# Altium schematic sheet Microcontroller_CC1350.SchDoc
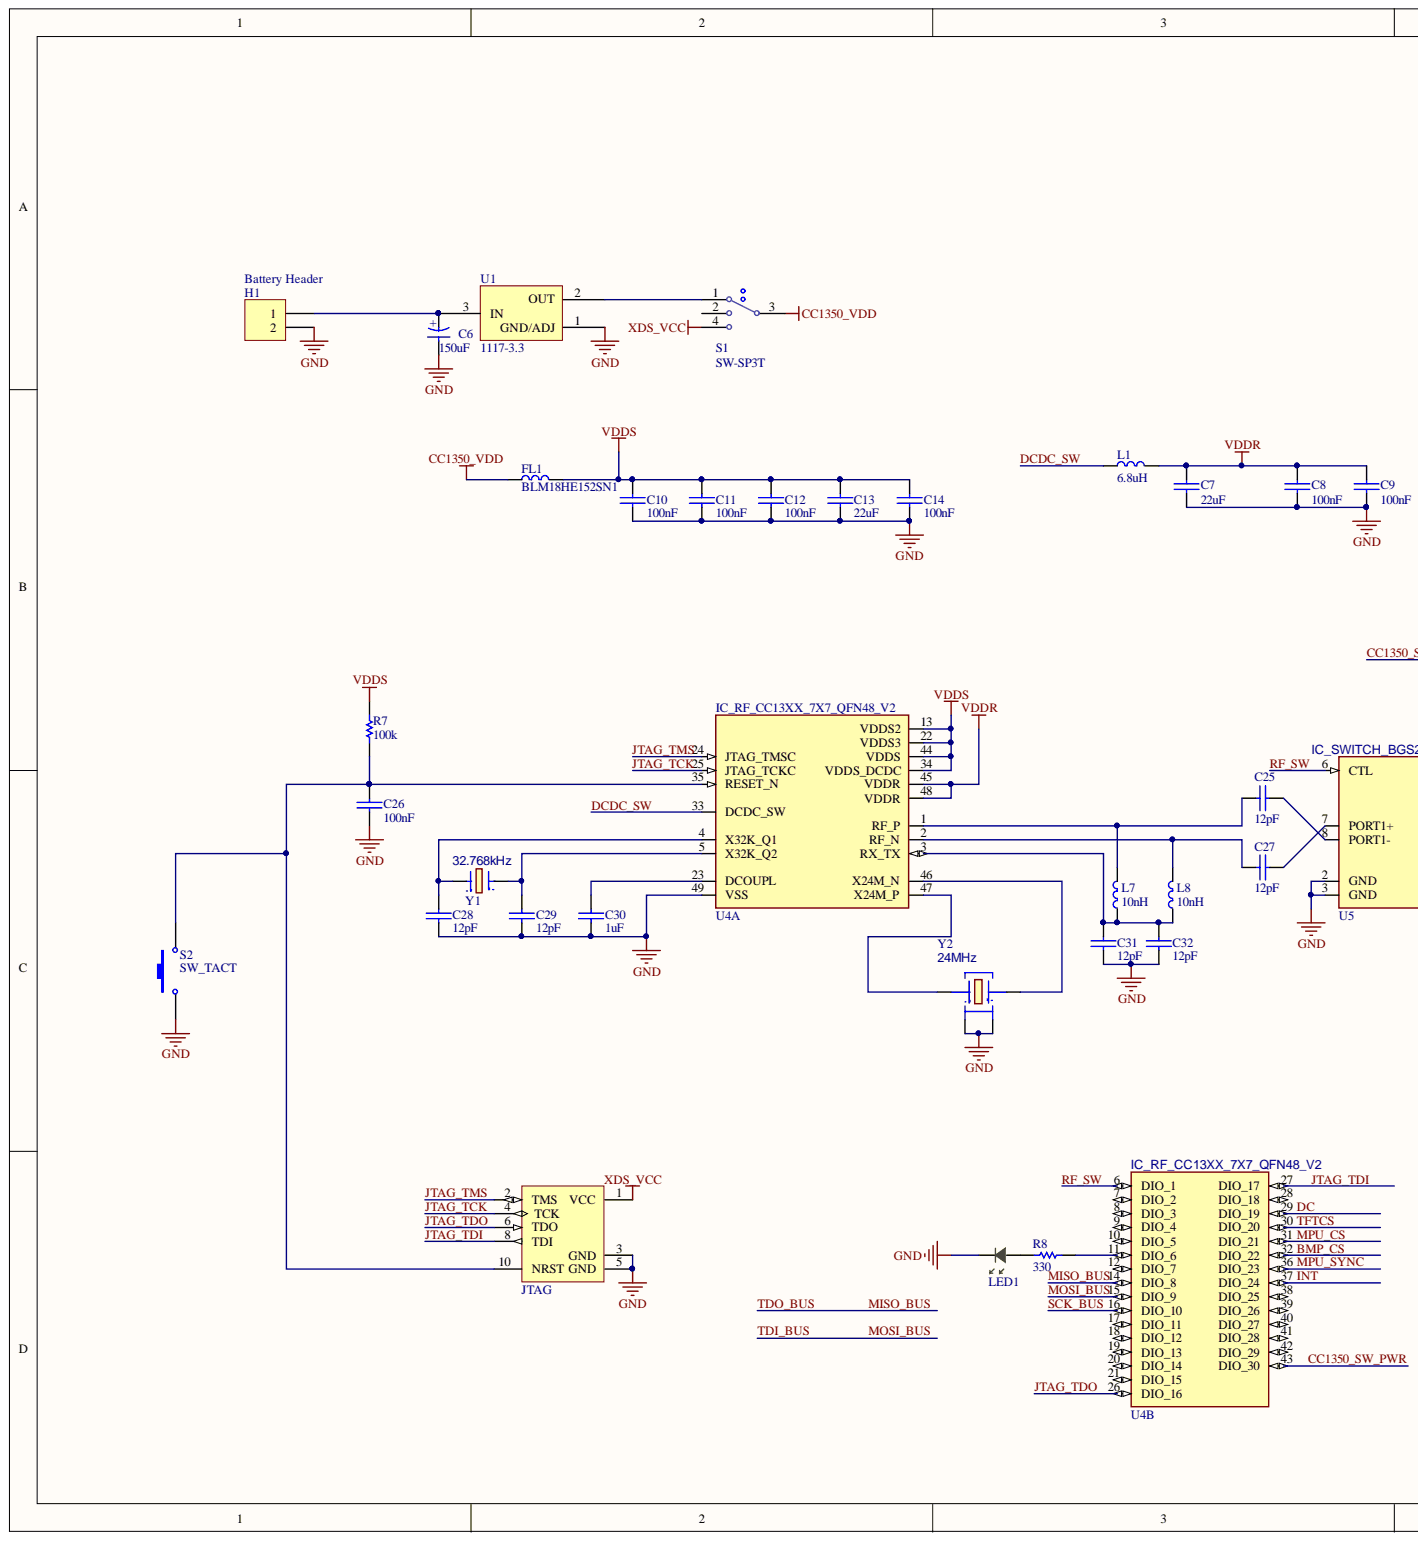
[diagram p0: Microcontroller_CC1350.SchDoc, left]
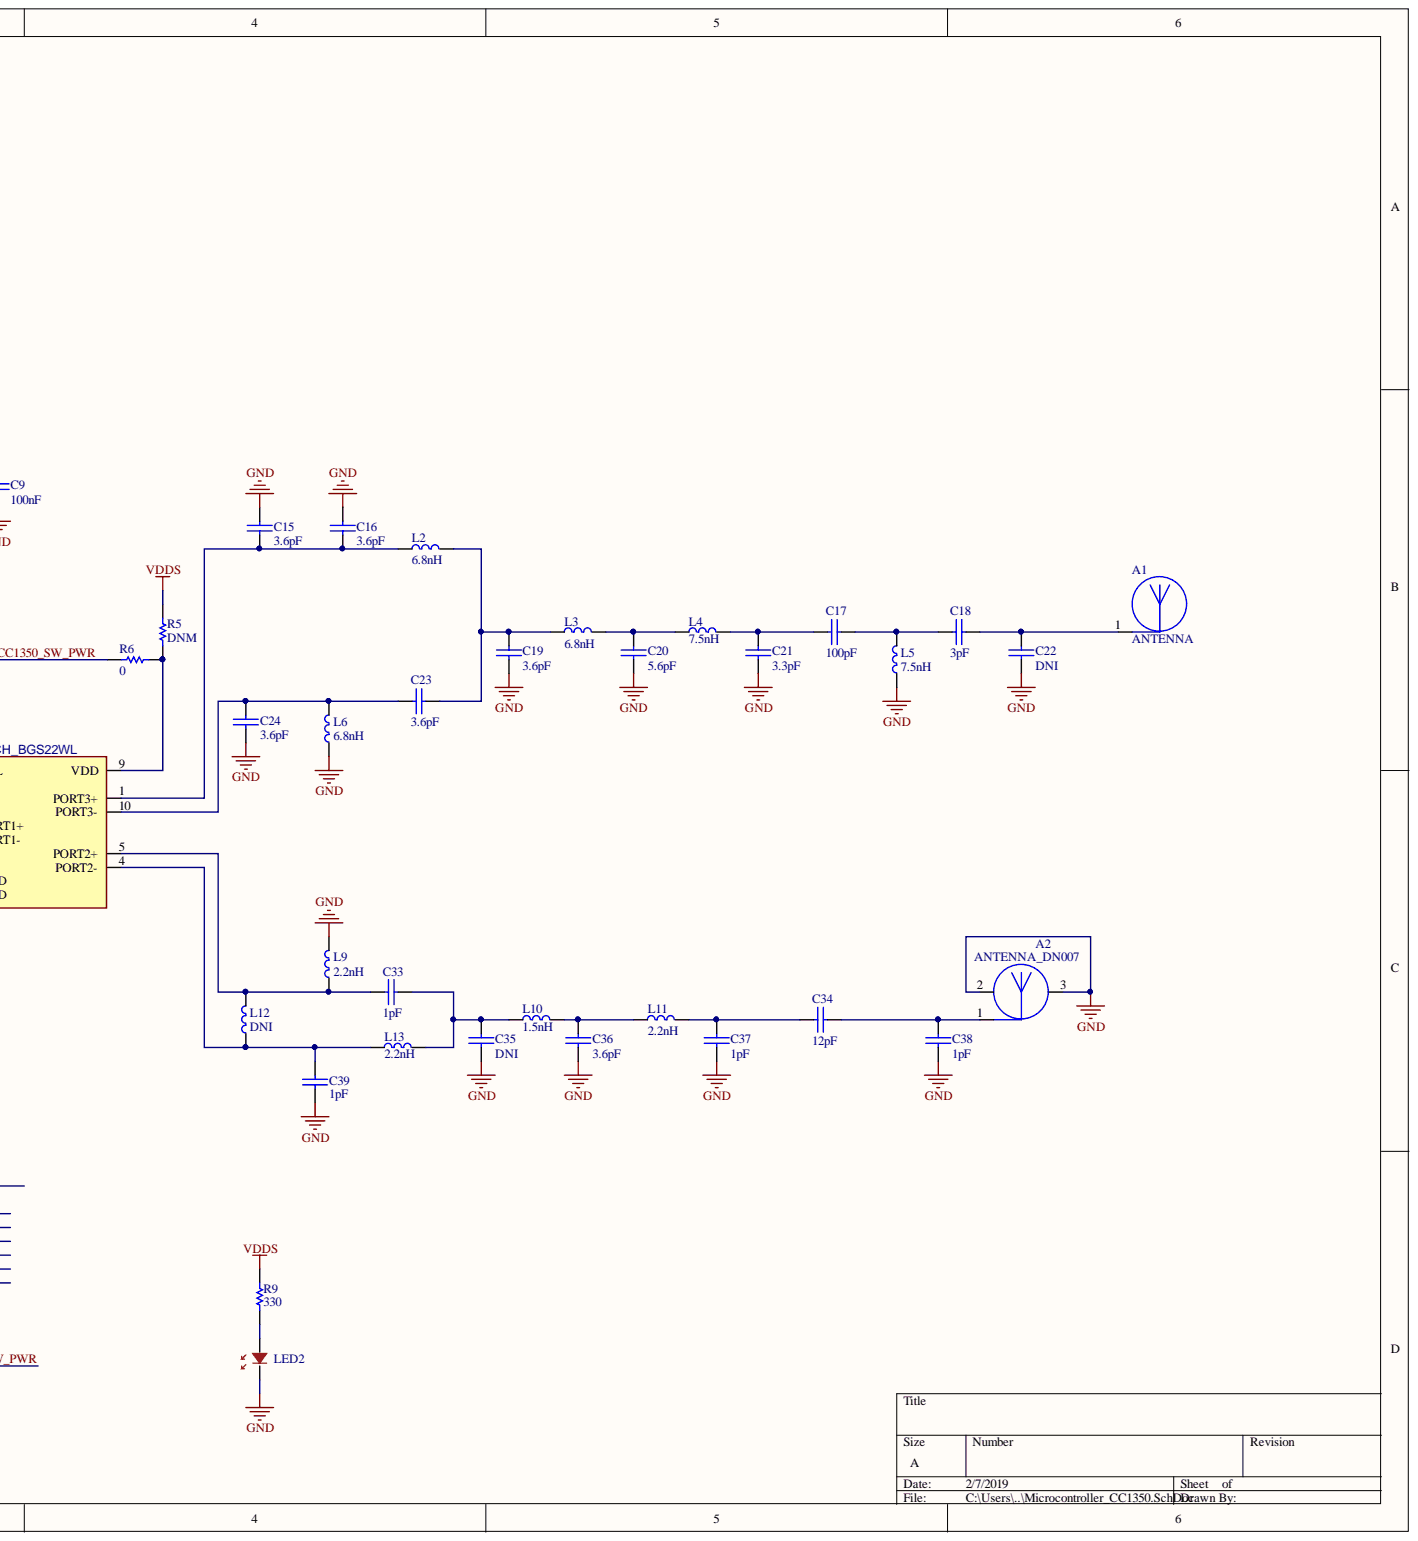
[diagram p1: Microcontroller_CC1350.SchDoc, right]

## Parts
A1  ANTENNA  [Sub-1GHz-Antenna]
A2  ANTENNA_DN007  [Inverted_F_Antenna]
C6  150uF  [2312 [IMP] Polar]
C7  22uF  [0603[IMP]]
C8  100nF  [0402[IMP]]
C9  100nF  [0402[IMP]]
C10  100nF  [0402[IMP]]
C11  100nF  [0402[IMP]]
C12  100nF  [0402[IMP]]
C13  22uF  [0603[IMP]]
C14  100nF  [0402[IMP]]
C15  3.6pF  [0402[IMP]]
C16  3.6pF  [0402[IMP]]
C17  100pF  [0402[IMP]]
C18  3pF  [0402[IMP]]
C19  3.6pF  [0402[IMP]]
C20  5.6pF  [0402[IMP]]
C21  3.3pF  [0402[IMP]]
C22  DNI  [0402[IMP]]
C23  3.6pF  [0402[IMP]]
C24  3.6pF  [0402[IMP]]
C25  12pF  [0402[IMP]]
C26  100nF  [0402[IMP]]
C27  12pF  [0402[IMP]]
C28  12pF  [0402[IMP]]
C29  12pF  [0402[IMP]]
C30  1uF  [0402[IMP]]
C31  12pF  [0402[IMP]]
C32  12pF  [0402[IMP]]
C33  1pF  [0402[IMP]]
C34  12pF  [0402[IMP]]
C35  DNI  [0402[IMP]]
C36  3.6pF  [0402[IMP]]
C37  1pF  [0402[IMP]]
C38  1pF  [0402[IMP]]
C39  1pF  [0402[IMP]]
FL1  BLM18HE152SN1  [0603[IMP]]
H1  Battery Header  [S2B-PH-SM4-TB]
H2  JTAG  [HDR-2*5-0.05_Without_Through_Hole]
L1  6.8uH  [0805[IMP]]
L2  6.8nH  [0402[IMP]]
L3  6.8nH  [0402[IMP]]
L4  7.5nH  [0402[IMP]]
L5  7.5nH  [0402[IMP]]
L6  6.8nH  [0402[IMP]]
L7  10nH  [0402[IMP]]
L8  10nH  [0402[IMP]]
L9  2.2nH  [0402[IMP]]
L10  1.5nH  [0402[IMP]]
L11  2.2nH  [0402[IMP]]
L12  DNI  [0402[IMP]]
L13  2.2nH  [0402[IMP]]
LED1  LEDG  [0805[IMP] - POLAR]  (value not drawn)
LED2  LEDR  [0805[IMP] - POLAR]  (value not drawn)
R5  DNM  [0402[IMP]]
R6  0  [0402[IMP]]
R7  100k  [0402[IMP]]
R8  330  [0402[IMP]]
R9  330  [0402[IMP]]
S1  SW-SP3T  [CL-SB-13B]
S2  SW_TACT  [PTS645SH50SMTR92_LFS]
U1  1117-3.3  [SOT-223]
U4  IC_RF_CC13XX_7X7_QFN48_V2  [RGZ(S-PVQFN-N48) - duplicate]  (parts A,B)
U5  IC_SWITCH_BGS22WL  [TSLP-10-1]
Y1  32.768kHz  [FC-135]
Y2  24MHz  [TSX-3225]

## Nets
CC1350_VDD: FL1.1 S1.3
GND: A2.2 A2.3 C6.1 C7.2 C8.2 C9.2 C10.2 C11.2 C12.2 C13.2 C14.2 C15.1 C16.1 C19.2 C20.2 C21.2 C22.1 C24.2 C26.2 C28.2 C29.2 C30.2 C31.2 C32.2 C35.1 C36.2 C37.1 C38.1 C39.2 H1.2 H2.3(GND) H2.5(GND) L5.1 L6.1 L9.2 LED1.2 LED2.2 S2.1 U1.1 U4.49(VSS) U5.2(GND) U5.3(GND) Y2.2 Y2.4
VDDR: C7.1 C8.1 C9.1 L1.2 U4.45(VDDR) U4.48(VDDR)
VDDS: C10.1 C11.1 C12.1 C13.1 C14.1 FL1.2 R5.2 R7.2 R9.2 U4.13(VDDS2) U4.22(VDDS3) U4.34(VDDS_DCDC) U4.44(VDDS)
XDS_VCC: H2.1(VCC) S1.4
BMP_CS: U4.32(DIO_22)
CC1350_SW_PWR: R6.2 U4.43(DIO_30)
DC: U4.29(DIO_19)
DCDC_SW: L1.1 U4.33(DCDC_SW)
INT: U4.37(DIO_24)
JTAG_TCK: H2.4(TCK) U4.25(JTAG_TCKC)
JTAG_TDI: H2.8(TDI) U4.27(DIO_17)
JTAG_TDO: H2.6(TDO) U4.26(DIO_16)
JTAG_TMS: H2.2(TMS) U4.24(JTAG_TMSC)
MISO_BUS: U4.14(DIO_8)
MOSI_BUS: U4.15(DIO_9)
MPU_CS: U4.31(DIO_21)
MPU_SYNC: U4.36(DIO_23)
RF_SW: U4.6(DIO_1) U5.6(CTL)
SCK_BUS: U4.16(DIO_10)
TFTCS: U4.30(DIO_20)
NetA1_1: A1.1 C18.2 C22.2
NetA2_1: A2.1 C34.2 C38.2
NetC6_2: C6.2 H1.1 U1.3
NetC15_2: C15.2 C16.2 L2.1 U5.1(PORT3+)
NetC17_1: C17.1 C21.1 L4.2
NetC17_2: C17.2 C18.1 L5.2
NetC19_1: C19.1 C23.2 L2.2 L3.1
NetC20_1: C20.1 L3.2 L4.1
NetC23_1: C23.1 C24.1 L6.2 U5.10(PORT3-)
NetC25_1: C25.1 L7.2 U4.1(RF_P)
NetC25_2: C25.2 U5.8(PORT1-)
NetC26_1: C26.1 H2.10(NRST) R7.1 S2.2 U4.35(RESET_N)
NetC27_1: C27.1 L8.2 U4.2(RF_N)
NetC27_2: C27.2 U5.7(PORT1+)
NetC28_1: C28.1 U4.4(X32K_Q1) Y1.1
NetC29_1: C29.1 U4.5(X32K_Q2) Y1.2
NetC30_1: C30.1 U4.23(DCOUPL)
NetC31_1: C31.1 C32.1 L7.1 L8.1 U4.3(RX_TX)
NetC33_1: C33.1 L9.1 L12.2 U5.5(PORT2+)
NetC33_2: C33.2 C35.2 L10.1 L13.2
NetC34_1: C34.1 C37.2 L11.2
NetC36_1: C36.1 L10.2 L11.1
NetC39_1: C39.1 L12.1 L13.1 U5.4(PORT2-)
NetLED1_1: LED1.1 R8.1
NetLED2_1: LED2.1 R9.1
NetR5_1: R5.1 R6.1 U5.9(VDD)
NetR8_2: R8.2 U4.11(DIO_6)
NetS1_1: S1.1 U1.2
NetU4_46: U4.46(X24M_N) Y2.3
NetU4_47: U4.47(X24M_P) Y2.1

Not connected: S1 2; U4 7(DIO_2) 8(DIO_3) 9(DIO_4) 10(DIO_5) 12(DIO_7) 17(DIO_11) 18(DIO_12) 19(DIO_13) 20(DIO_14) 21(DIO_15) 28(DIO_18) 38(DIO_25) 39(DIO_26) 40(DIO_27) 41(DIO_28) 42(DIO_29)

## Library data of the parts
A1 = ANTENNA: CLASS: DISCRETE; Designed by: Pawel Kwaskiewicz; Component Kind: Standard; Design date: 2010-02-22; Library Name: Biosense_Altium_Library.IntLib
A2 = ANTENNA_DN007: Designed by: Artur Bielinski; Component Kind: Standard; Design date: 2011-09-19; Library Name: Biosense_Altium_Library.IntLib
C6 = Cap_E: Capacitor; Component Kind: Standard; Supplier 1: Digi-Key; Supplier Part Number 1: 478-3481-1-ND; Value: 150uF; Library Name: Biosense_Altium_Library.IntLib
C7-C39 = CAPACITOR: CLASS: DISCRETE; CURRENT: CIMAX; DIST: FLAT; KNEE: CBMAX; MAX_TEMP: CTMAX; PSpiceTemplate: C^@REFDES %1 %2 ?TOLERANCE|C^@REFDES| @VALUE ?IC/IC=@IC/ TC=@TC1,@TC2 ?TOLERANCE|\n.model C^@REFDES CAP C=1 DEV=@TOLERANCE%|; SLOPE: CSMAX; TC1: 0; VOLTAGE: CMAX; Component Kind: Standard; Simulation: CAPACITOR; TC2: 0; Library Name: Biosense_Altium_Library.IntLib
  C7 C13: Supplier 1: Digi-Key; Supplier Part Number 1: 490-7611-1-ND; Value: 22uF
  C8-C12 C14 C26: Supplier 1: Digi-Key; Supplier Part Number 1: 490-6327-1-ND; Value: 100nF
  C15 C19 C23 C24 C36: Supplier 1: Digi-Key; Supplier Part Number 1: 490-5939-1-ND; Value: 3.6pF
  C16: Supplier 1: Digi-Key; Supplier 2: Digi-Key; Supplier Part Number 1: 399-14982-1-ND; Supplier Part Number 2: 490-5939-1-ND; Value: 3.6pF
  C17: Supplier 1: Digi-Key; Supplier Part Number 1: 490-7753-1-ND; Value: 100pF
  C18: Supplier 1: Digi-Key; Supplier Part Number 1: 712-1282-1-ND; Value: 3pF
  C20: Supplier 1: Digi-Key; Supplier Part Number 1: 490-6250-1-ND; Value: 5.6pF
  C21: Supplier 1: Digi-Key; Supplier Part Number 1: 490-5938-1-ND; Value: 3.3pF
  C22 C35: Value: DNI
  C25 C27-C29 C31 C32: Supplier 1: Digi-Key; Supplier Part Number 1: 399-1013-1-ND; Value: 12pF
  C30: Supplier 1: Digi-Key; Supplier Part Number 1: 1276-1448-1-ND; Value: 1uF
  C33 C37-C39: Supplier 1: Digi-Key; Supplier Part Number 1: 490-3199-1-ND; Value: 1pF
  C34: Supplier 1: Digi-Key; Supplier Part Number 1: 490-5924-1-ND; Value: 12pF
FL1 L1-L13 = INDUCTOR: Current: LMAX; DIELECTRIC: DSMAX; DIST: FLAT; PSpiceTemplate: L^@REFDES %1 %2 ?TOLERANCE|L^@REFDES| @VALUE ?IC/IC=@IC/ ?TOLERANCE|\n.model l^@REFDES IND L=1 DEV=@TOLERANCE%|; Component Kind: Standard; Simulation: INDUCTOR; Library Name: Biosense_Altium_Library.IntLib
  FL1: Supplier 1: Digi-Key; Supplier Part Number 1: 490-5216-1-ND; Value: BLM18HE152SN1
  L1: Supplier 1: Digi-Key; Supplier Part Number 1: 445-6761-1-ND; Value: 6.8uH
  L2 L6: Supplier 1: Digi-Key; Supplier Part Number 1: 712-1419-1-ND; Value: 6.8nH
  L3: Supplier 1: Digi-Key; Supplier Part Number 1: 587-1543-1-ND; Value: 6.8nH
  L4 L5: Supplier 1: Digi-Key; Supplier Part Number 1: 490-3456-1-ND; Value: 7.5nH
  L7 L8: Supplier 1: Digi-Key; Supplier Part Number 1: 712-1407-1-ND; Value: 10nH
  L9 L11 L13: Supplier 1: Digi-Key; Supplier Part Number 1: 490-6794-1-ND; Value: 2.2nH
  L10: Supplier 1: Digi-Key; Supplier Part Number 1: 490-6783-1-ND; Value: 1.5nH
  L12: Value: DNI
H1 = Header 2: Component Kind: Standard; Supplier 1: Digi-Key; Supplier Part Number 1: 455-1749-1-ND; Library Name: Biosense_Altium_Library.IntLib
H2 = JTAG-ARM: USB JTAG Programmer; Component Kind: Standard; Supplier 1: Digi-Key; Supplier Part Number 1: SAM13160CT-ND; Library Name: Biosense_Altium_Library.IntLib
LED1 = LED_G: LED; Component Kind: Standard; Supplier 1: Digi-Key; Supplier 2: Digi-Key; Supplier Part Number 2: 475-1410-1-ND; Value: ?; Library Name: Biosense_Altium_Library.IntLib
LED2 = LED_R: LED; Component Kind: Standard; Supplier 1: Digi-Key; Supplier Part Number 1: 475-1278-1-ND; Value: ?; Library Name: Biosense_Altium_Library.IntLib
R5-R9 = RESISTOR: Component Kind: Standard; Library Name: Biosense_Altium_Library.IntLib
  R5: Value: DNM
  R6: Supplier 1: Digi-Key; Supplier Part Number 1: 541-0.0YBCT-ND; Value: 0
  R7: Supplier 1: Digi-Key; Supplier Part Number 1: 541-100KYACT-ND; Value: 100k
  R8 R9: Supplier 1: Digi-Key; Supplier Part Number 1: P330LCT-ND; Value: 330
S2 = SW_TACT: Tactile switch; Component Kind: Standard; Supplier 1: Digi-Key; Supplier Part Number 1: CKN9085CT-ND; Library Name: Biosense_Altium_Library.IntLib
U1 = 1117-3.3V: 3.3V Voltage Regulator; Component Kind: Standard; Supplier 1: Digi-Key; Supplier Part Number 1: LM1117IMPX-3.3/NOPBCT-ND; Library Name: Biosense_Altium_Library.IntLib
U4 = IC_RF_CC13XX_7X7_QFN48_V2: Designed_By: Artur Bielinski; SPLIT_INST: TRUE; SWAP_INFO: (S1+S2); Component Kind: Standard; Design_Date: 2014-09-04; Supplier 1: Digi-Key; Supplier Part Number 1: 296-44716-1-ND; Library Name: Biosense_Altium_Library.IntLib
U5 = IC_SWITCH_XMSSJE3G0PA-00: CLASS: IC; Designed_By: Artur Bielinski; Component Kind: Standard; Design_Date: 2015-06-03; Supplier 1: Digi-Key; Supplier Part Number 1: BGS22WL10E6327XTSA1CT-ND; Library Name: Biosense_Altium_Library.IntLib
Y1 = CRYSTAL: Component Kind: Standard; Supplier 1: Digi-Key; Supplier Part Number 1: SER4089CT-ND; Library Name: Biosense_Altium_Library.IntLib
Y2 = XTAL_4PIN_S: Component Kind: Standard; Supplier 1: Digi-Key; Supplier Part Number 1: SER3635CT-ND; Library Name: Biosense_Altium_Library.IntLib
S1 = SW-SP3T: Component Kind: Standard; Library Name: Biosense_Altium_Library.IntLib
